# Altium schematic sheet LED_high_power.SchDoc(HP_LED)
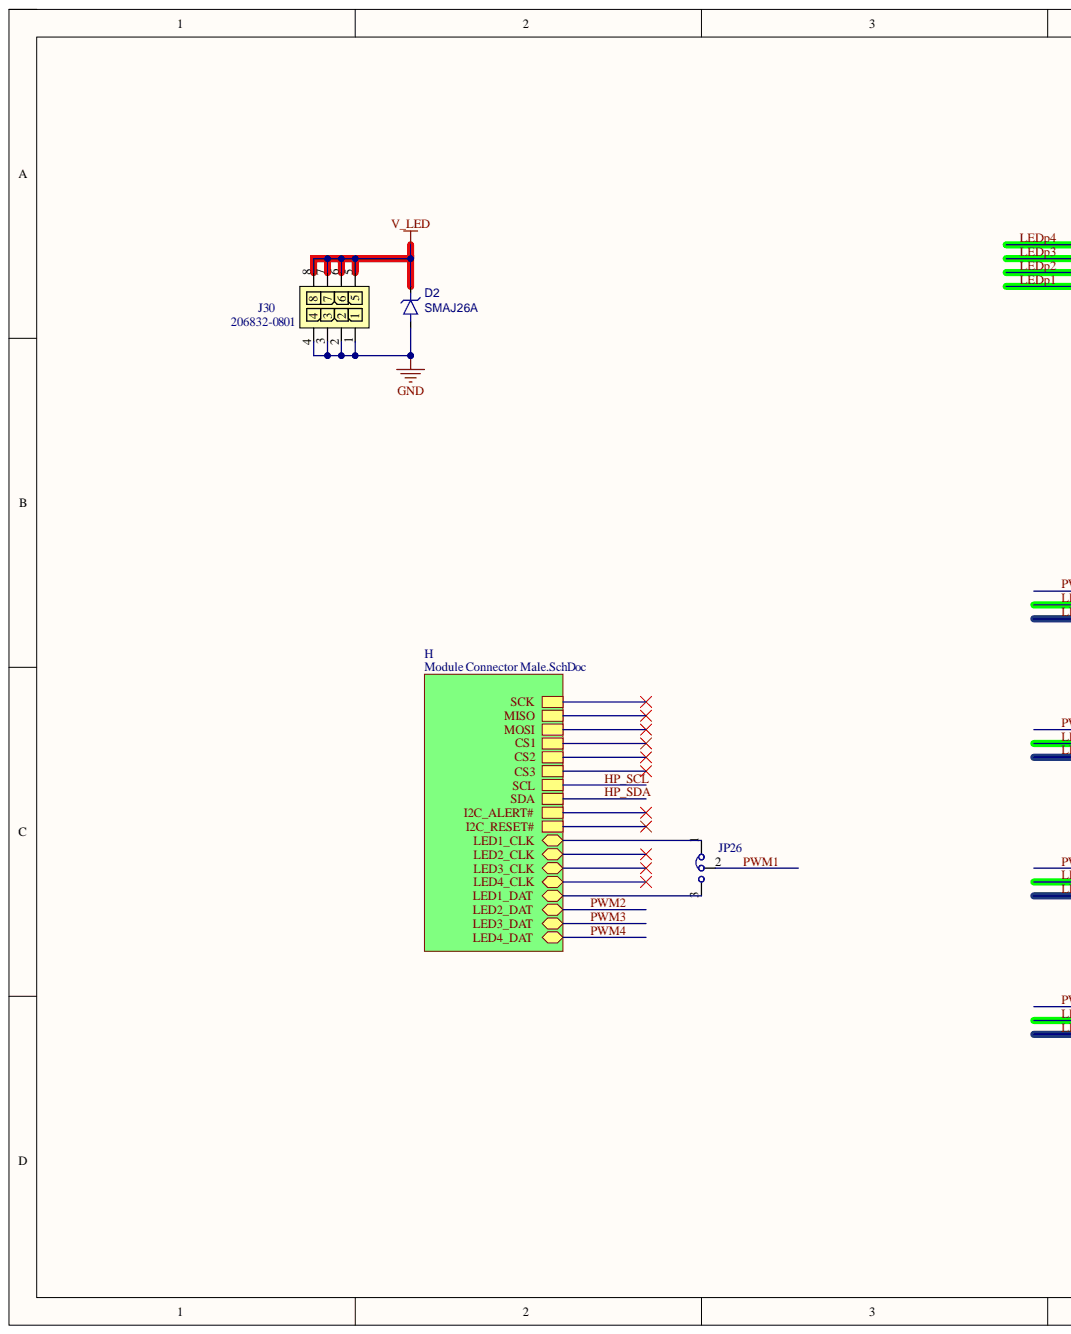
[diagram p0: LED_high_power.SchDoc, left]
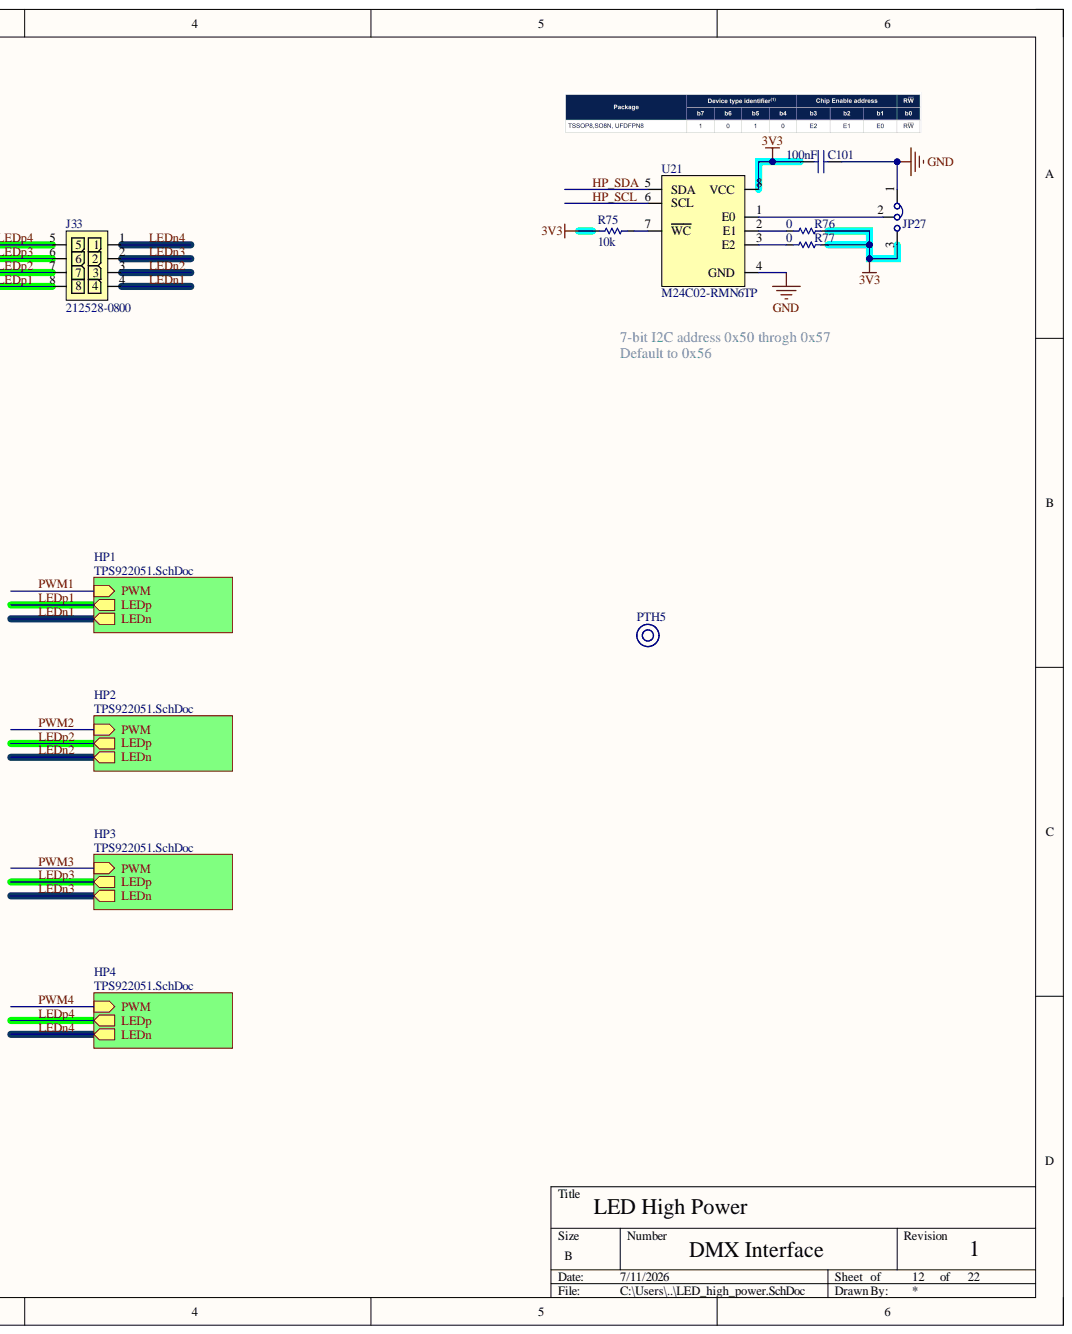
[diagram p1: LED_high_power.SchDoc, right]

## Parts
C101  value 100nF  [CAPC1608X10N_0603_MED]  (hidden comment: CC0603KRX7R0BB104)
D2  SMAJ26A  [FP-SMAJ-MFG]
J30  206832-0801  [206832-0801-Footprint-1]
J33  212528-0800  [212528-0800-Footprint]
JP26  Jumper 3 x 1 Bridge 1-2 Solder Bridge  [Jumper 3 Solder Bridge 1-2 0603]  (value not drawn)
JP27  Jumper 3 x 1 Bridge 1-2 Solder Bridge  [Jumper 3 Solder Bridge 1-2 0603]  (value not drawn)
PTH5  Mounting Hole NPTH  [Mounting Hole M3 No Washer NPTH]  (value not drawn)  (no BOM)
R75  value 10k  [RESC1608X06N_0603_MED]  (hidden comment: RC0603FR-1010KL)
R76  value 0  [RESC1608X06N_0603_MED]  (hidden comment: RC0603FR-070RL)
R77  value 0  [RESC1608X06N_0603_MED]  (hidden comment: RC0603FR-070RL)
U21  M24C02-RMN6TP  [M24C02-RMN6TP-SO8N-IPC_B]

## Nets
3V3: C101.1 JP27.3 R75.2 R76.1 R77.1 U21.8(VCC)
GND: C101.2 D2.2 J30.1 J30.2 J30.3 J30.4 JP27.1 U21.4(GND)
V_LED: D2.1 J30.5 J30.6 J30.7 J30.8
HP_SCL: U21.6(SCL)
HP_SDA: U21.5(SDA)
LEDn1: J33.4
LEDn2: J33.3
LEDn3: J33.2
LEDn4: J33.1
LEDp1: J33.8
LEDp2: J33.7
LEDp3: J33.6
LEDp4: J33.5
PWM1: JP26.2
NetJP26_1: JP26.1
NetJP26_3: JP26.3
NetJP27_2: JP27.2 U21.1(E0)
NetR75_1: R75.1 U21.7(WC)
NetR76_2: R76.2 U21.2(E1)
NetR77_2: R77.2 U21.3(E2)
CS1:
CS2:
CS3:
I2C_ALERT#:
I2C_RESET#:
LED2_CLK:
LED3_CLK:
LED4_CLK:
MISO:
MOSI:
PWM2:
PWM3:
PWM4:
SCK:

## Library data of the parts
C101 = CMP-001-00102-4: Ceramic Capacitor, Multilayer, Ceramic, 100V, 10% +Tol, 10% -Tol, X7R, 15% TC, 0.1uF, Surface Mount, 0603; Capacitor Type: Ceramic; Case/Package: 0603; Component Kind: Standard; JLCPN: C113803; Max Operating Temperature: 125°C; Min Operating Temperature: -55°C; Mounting Technology: Surface Mount; Pins: 2; RoHS Compliant: Yes; Voltage Rating: 100V; Library Name: Equus Computer Systems
D2 = CMP-004-00046-2: Trans Voltage Suppressor Diode, 400W, 26V V(RWM), Unidirectional, 1 Element, Silicon, DO-214AC; Component Kind: Standard; JLCPN: C148225; Max Operating Temperature: 150°C; Min Operating Temperature: -65°C; Pins: 2; RoHS Compliant: Yes; Library Name: Equus Computer Systems
J30 = CMP-002-00208-6: Micro-Fit+, Vertical Header, 8 pin; Max Current Rating: 12.5A; Component Kind: Standard; Connector Type: Micro-Fit+; Mounting Technology: Through Hole; Pins: 8; Library Name: Equus Computer Systems
J33 = CMP-002-00231-1: Micro-Fit+ RA Header 8 Position; Gender: Male; Max Current Rating: 12.5A; Component Kind: Standard; Connector Type: Micro-Fit+; Mounting Technology: Through Hole; Pins: 8; Library Name: Equus Computer Systems
JP26 JP27 = CMP-009-00341-2: 0603 Solder Bridge Jumper Surface Mount; Tolerance: 1%; Value: 0; Voltage Rating: 75V; Case/Package: 0603; Component Kind: Standard; Max Operating Temperature: 155°C; Min Operating Temperature: -55°C; Mounting Technology: Surface Mount; Pins: 2; RoHS Compliant: Yes; Library Name: Equus Computer Systems
PTH5 = CMP-00028-00043-3: Description: Mounting Hole NPTH; Library Name: Equus Computer Systems
R75 = CMP-009-00306-2: Fixed Resistor, Metal Glaze/thick Film, 0.1W, 10000ohm, 75V, 1% +/-Tol, 100ppm/Cel, Surface Mount, 0603; Power: 100mW; Voltage Rating: 50V; Case/Package: 0603; Component Kind: Standard; JLCPN: C98220; Max Operating Temperature: 155°C; Min Operating Temperature: -55°C; Mounting Technology: Surface Mount; Pins: 2; RoHS Compliant: Yes; Tolerance: 1%; Library Name: Equus Computer Systems
R76 R77 = CMP-009-00204-3: RC Series 0603 0.1 W 0 Ohm Jumper Surface Mount Thick Film Chip Resistor; Power: 100mW; Tolerance: 1%; Voltage Rating: 75V; Case/Package: 0603; Component Kind: Standard; JLCPN: C100044; Max Operating Temperature: 155°C; Min Operating Temperature: -55°C; Mounting Technology: Surface Mount; Pins: 2; RoHS Compliant: Yes; Library Name: Equus Computer Systems
U21 = CMP-019-00078-2: M24C02 Series 2 Kb (256 x 8) 1.8 V SMT Serial I²C Bus EEPROM - SOIC-8; Clock Frequency: 400kHz; Max Supply Voltage: 5.5V; Memory Size: 256B; Min Supply Voltage: 1.8V; Case/Package: SOIC; Component Kind: Standard; Frequency: 400kHz; JLCPN: C83836; Max Operating Temperature: 85°C; Memory Format: EEPROM; Min Operating Temperature: -40°C; Mounting Technology: Surface Mount; Pins: 8; Library Name: Equus Computer Systems
